# Altium schematic sheet schematic.SchDoc
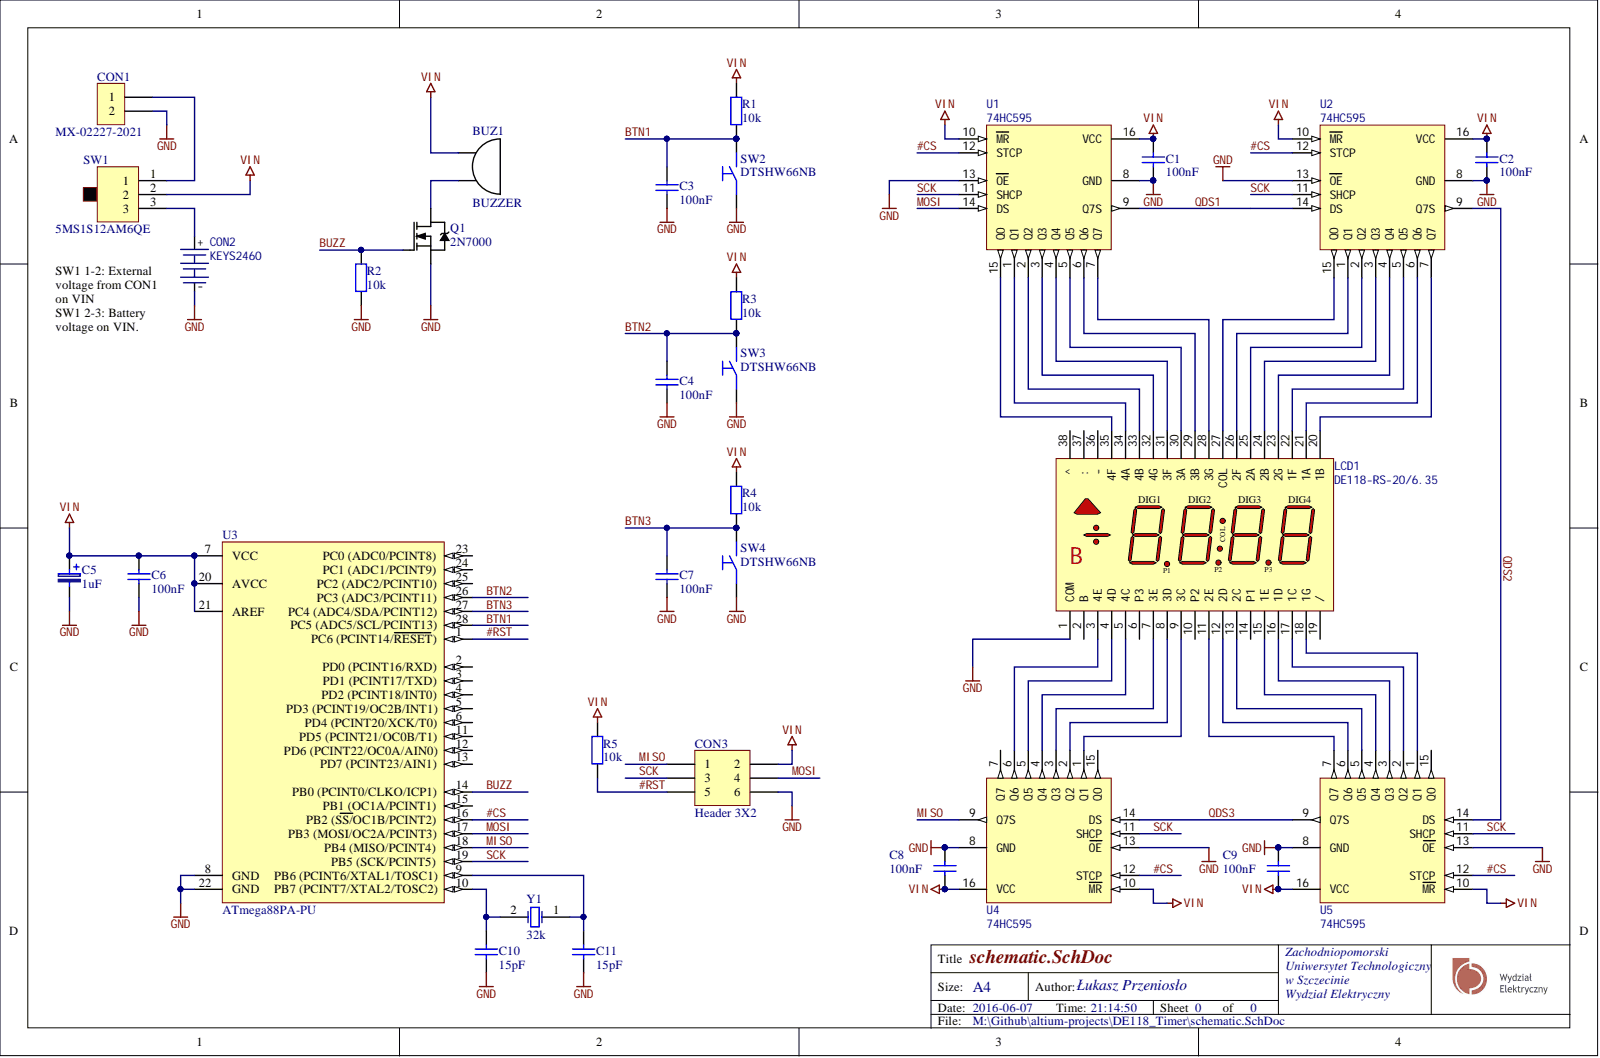
[diagram p0: schematic.SchDoc]

## Parts
BUZ1  BUZZER  [BUZZER-14mm]
C1  100nF  [CAP-5x2.5]
C2  100nF  [CAP-5x2.5]
C3  100nF  [CAP-5x2.5]
C4  100nF  [CAP-5x2.5]
C5  1uF  [CAPPR3.5-8x12]
C6  100nF  [CAP-5x2.5]
C7  100nF  [CAP-5x2.5]
C8  100nF  [CAP-5x2.5]
C9  100nF  [CAP-5x2.5]
C10  15pF  [CAP-5x2.5]
C11  15pF  [CAP-5x2.5]
CON1  MX-02227-2021  [MX-02227-2021]
CON2  KEYS2460  [BAT_HOLDER_2479RB]
CON3  Header 3X2  [HDR2X3_IDC]
LCD1  DE118-RS-20/6.35  [DE118]
Q1  2N7000  [TO92_WIDE]
R1  10k  [RES_AXIAL_0W25]
R2  10k  [RES_AXIAL_0W25]
R3  10k  [RES_AXIAL_0W25]
R4  10k  [RES_AXIAL_0W25]
R5  10k  [RES_AXIAL_0W25]
SW1  5MS1S12AM6QE  [5MS1S12AM6QE]
SW2  DTSHW66NB  [FSM2J]
SW3  DTSHW66NB  [FSM2J]
SW4  DTSHW66NB  [FSM2J]
U1  74HC595  [DIP16]
U2  74HC595  [DIP16]
U3  ATmega88PA-PU  [DIP28]
U4  74HC595  [DIP16]
U5  74HC595  [DIP16]
Y1  32k  [HC-49U]

## Nets
GND: C1.1 C2.1 C3.1 C4.1 C5.2 C6.1 C7.1 C8.1 C9.1 C10.1 C11.1 CON1.2 CON2.2 CON3.6 LCD1.1(COM) Q1.3 R2.1 SW2.1 SW3.1 SW4.1 U1.8(GND) U1.13(OE) U2.8(GND) U2.13(OE) U3.8(GND) U3.22(GND) U4.8(GND) U4.13(OE) U5.8(GND) U5.13(OE)
VIN: BUZ1.1 C1.2 C2.2 C5.1 C6.2 C8.2 C9.2 CON3.2 R1.2 R3.2 R4.2 R5.2 SW1.2 U1.10(MR) U1.16(VCC) U2.10(MR) U2.16(VCC) U3.7(VCC) U3.20(AVCC) U3.21(AREF) U4.10(MR) U4.16(VCC) U5.10(MR) U5.16(VCC)
#CS: U1.12(STCP) U2.12(STCP) U3.16(PB2_(SS/OC1B/PCINT2)) U4.12(STCP) U5.12(STCP)
#RST: CON3.5 R5.1 U3.1(PC6_(PCINT14/RESET))
BTN1: C3.2 R1.1 SW2.2 U3.28(PC5_(ADC5/SCL/PCINT13))
BTN2: C4.2 R3.1 SW3.2 U3.26(PC3_(ADC3/PCINT11))
BTN3: C7.2 R4.1 SW4.2 U3.27
BUZZ: Q1.2 R2.2 U3.14(PB0_(PCINT0/CLKO/ICP1))
MISO: CON3.1 U3.18(PB4_(MISO/PCINT4)) U4.9(Q7S)
MOSI: CON3.4 U1.14(DS) U3.17(PB3_(MOSI/OC2A/PCINT3))
QDS1: U1.9(Q7S) U2.14(DS)
QDS2: U2.9(Q7S) U5.14(DS)
QDS3: U4.14(DS) U5.9(Q7S)
SCK: CON3.3 U1.11(SHCP) U2.11(SHCP) U3.19(PB5_(SCK/PCINT5)) U4.11(SHCP) U5.11(SHCP)
NetBUZ1_2: BUZ1.2 Q1.1
NetC10_2: C10.2 U3.10 Y1.2
NetC11_2: C11.2 U3.9 Y1.1
NetCON1_1: CON1.1 SW1.1
NetCON2_1: CON2.1 SW1.3
NetLCD1_3: LCD1.3(4E) U4.6(Q6)
NetLCD1_4: LCD1.4(4D) U4.5(Q5)
NetLCD1_5: LCD1.5(4C) U4.4(Q4)
NetLCD1_7: LCD1.7(3E) U4.3(Q3)
NetLCD1_8: LCD1.8(3D) U4.2(Q2)
NetLCD1_9: LCD1.9(3C) U4.1(Q1)
NetLCD1_11: LCD1.11(2E) U5.7(Q7)
NetLCD1_12: LCD1.12(2D) U5.6(Q6)
NetLCD1_13: LCD1.13(2C) U5.5(Q5)
NetLCD1_15: LCD1.15(1E) U5.4(Q4)
NetLCD1_16: LCD1.16(1D) U5.3(Q3)
NetLCD1_17: LCD1.17(1C) U5.2(Q2)
NetLCD1_18: LCD1.18(1G) U5.1(Q1)
NetLCD1_20: LCD1.20(1B) U2.7(Q7)
NetLCD1_21: LCD1.21(1A) U2.6(Q6)
NetLCD1_22: LCD1.22(1F) U2.5(Q5)
NetLCD1_23: LCD1.23(2G) U2.4(Q4)
NetLCD1_24: LCD1.24(2B) U2.3(Q3)
NetLCD1_25: LCD1.25(2A) U2.2(Q2)
NetLCD1_26: LCD1.26(2F) U2.1(Q1)
NetLCD1_27: LCD1.27(COL) U2.15(Q0)
NetLCD1_28: LCD1.28(3G) U1.7(Q7)
NetLCD1_29: LCD1.29(3B) U1.6(Q6)
NetLCD1_30: LCD1.30(3A) U1.5(Q5)
NetLCD1_31: LCD1.31(3F) U1.4(Q4)
NetLCD1_32: LCD1.32(4G) U1.3(Q3)
NetLCD1_33: LCD1.33(4B) U1.2(Q2)
NetLCD1_34: LCD1.34(4A) U1.1(Q1)
NetLCD1_35: LCD1.35(4F) U1.15(Q0)

Not connected: LCD1 2(B) 6(P3) 10(P2) 14(P1) 19(/) 36(-) 37(:) 38(^); U3 2(PD0_(PCINT16/RXD)) 3(PD1_(PCINT17/TXD)) 4(PD2_(PCINT18/INT0)) 5(PD3_(PCINT19/OC2B/INT1)) 6(PD4_(PCINT20/XCK/T0)) 11(PD5_(PCINT21/OC0B/T1)) 12(PD6_(PCINT22/OC0A/AIN0)) 13(PD7_(PCINT23/AIN1)) 15(PB1_(OC1A/PCINT1)) 23(PC0_(ADC0/PCINT8)) 24(PC1_(ADC1/PCINT9)) 25; U4 7(Q7) 15(Q0); U5 15(Q0)

## Library data of the parts
C1-C4 C6-C11 = Cap: Capacitor; Component Kind: Standard; LatestRevisionDate: 17-Jul-2002; LatestRevisionNote: Re-released for DXP Platform.; Library Name: Miscellaneous Devices.IntLib; Published: 8-Jun-2000; Signal Integrity: Cap; Simulation: CAP; Publisher: Altium Limited
C5 = Capacitor Tantalum: Component Kind: Standard; Description: Capacitor Tantalum; Library Name: Discrete.SchLib; Signal Integrity: Cap; Simulation: CAP; Value: 1uF
CON1 = MX-02227-2021: Pith 2.54mm, 2-pin; Component Kind: Standard; ComponentLink1Description: Manufacturer Link; ComponentLink2Description: Datasheet; Distributor: tme.pl; Library Name: Connectors.SchLib; tme.pl: MX-6410-03A
CON2 = KEYS2460: Holds Three (3) “AAA” Cells in Series; Component Kind: Standard; Library Name: my_various_devices.SchLib
CON3 = Header 3X2: Header, 3-Pin, Dual row; Component Kind: Standard; LatestRevisionDate: 17-Jul-2002; LatestRevisionNote: Re-released for DXP Platform.; Library Name: Miscellaneous Connectors.IntLib; Signal Integrity: Connector; Publisher: Altium Limited
LCD1 = DE118-RS-20/6.35: Wyświetlacz: LCD; cyfrowy; STN Positive; Il.cyfr:4; Znak:8mm; Component Kind: Standard; Library Name: my_various_devices.SchLib
R1-R5 = Resistor: Power: 0W; Tolerance: 5%; Component Kind: Standard; Description: Resistor; Library Name: Discrete.SchLib; Signal Integrity: Res; Simulation: RESISTOR; Value: 10k
SW2-SW4 = DTSHW66NB: SWITCH, SPNO TACT; Component Kind: Standard; ComponentLink1Description: Manufacturer Link; ComponentLink2Description: Datasheet; Distributor: tme.pl; LatestRevisionDate: 17-Jul-2002; LatestRevisionNote: Re-released for DXP Platform.; Library Name: Other.SchLib; PackageDocument: Sep-1998; PackageReference: SOT-23A; Published: 8-Jun-2000; tme.pl: DTSHW66NB; Publisher: Altium Limited
U1 U2 U4 U5 = 74HC595: 8-bit serial-in, serial or parallel-out shift register with output latches; 3-state; Component Kind: Standard; Library Name: my_various_devices.SchLib
U3 = ATmega88PA-PU: 8-bit AVR Microcontroller, 8KB Flash, 512 Bytes EEPROM, 1KB SRAM, 28-pin PDIP, Industrial Grade (-40°C to 85°C); Component Kind: Standard; ComponentLink1Description: Manufacturer URL; ComponentLink1URL: http://www.atmel.com/; ComponentLink2Description: ATmega48A/48PA/88A/88PA/168A/168PA/328/328P Datasheet; ComponentLink2URL: http://www.atmel.com/dyn/resources/prod_documents/8271.pdf; ComponentLink3Description: ATmega48A/48PA/88A/88PA/168A/168PA/328/328P Summary; ComponentLink3URL: http://www.atmel.com/dyn/resources/prod_documents/8271S.pdf; DatasheetVersion: revD, May-2011; PackageReference: 28P3; PackageVersion: revB, Sep-2001; RevisionNote: DatasheetVersion updated. URLs added.; Library Name: my_various_devices.SchLib
Y1 = XTAL: Crystal Oscillator; Component Kind: Standard; Library Name: Discrete.SchLib; Simulation: XTAL; Value: 32k
BUZ1 = BUZZER: Component Kind: Standard; Description: BUZZER; Library Name: my_various_devices.SchLib
Q1 = 2N7000: Component Kind: Standard; Library Name: my_various_devices.SchLib
SW1 = 5MS1S12AM6QE: Component Kind: Standard; Description: 5MS1S12AM6QE; Library Name: my_various_devices.SchLib
